# Altium schematic sheet Page A_Power.SchDoc
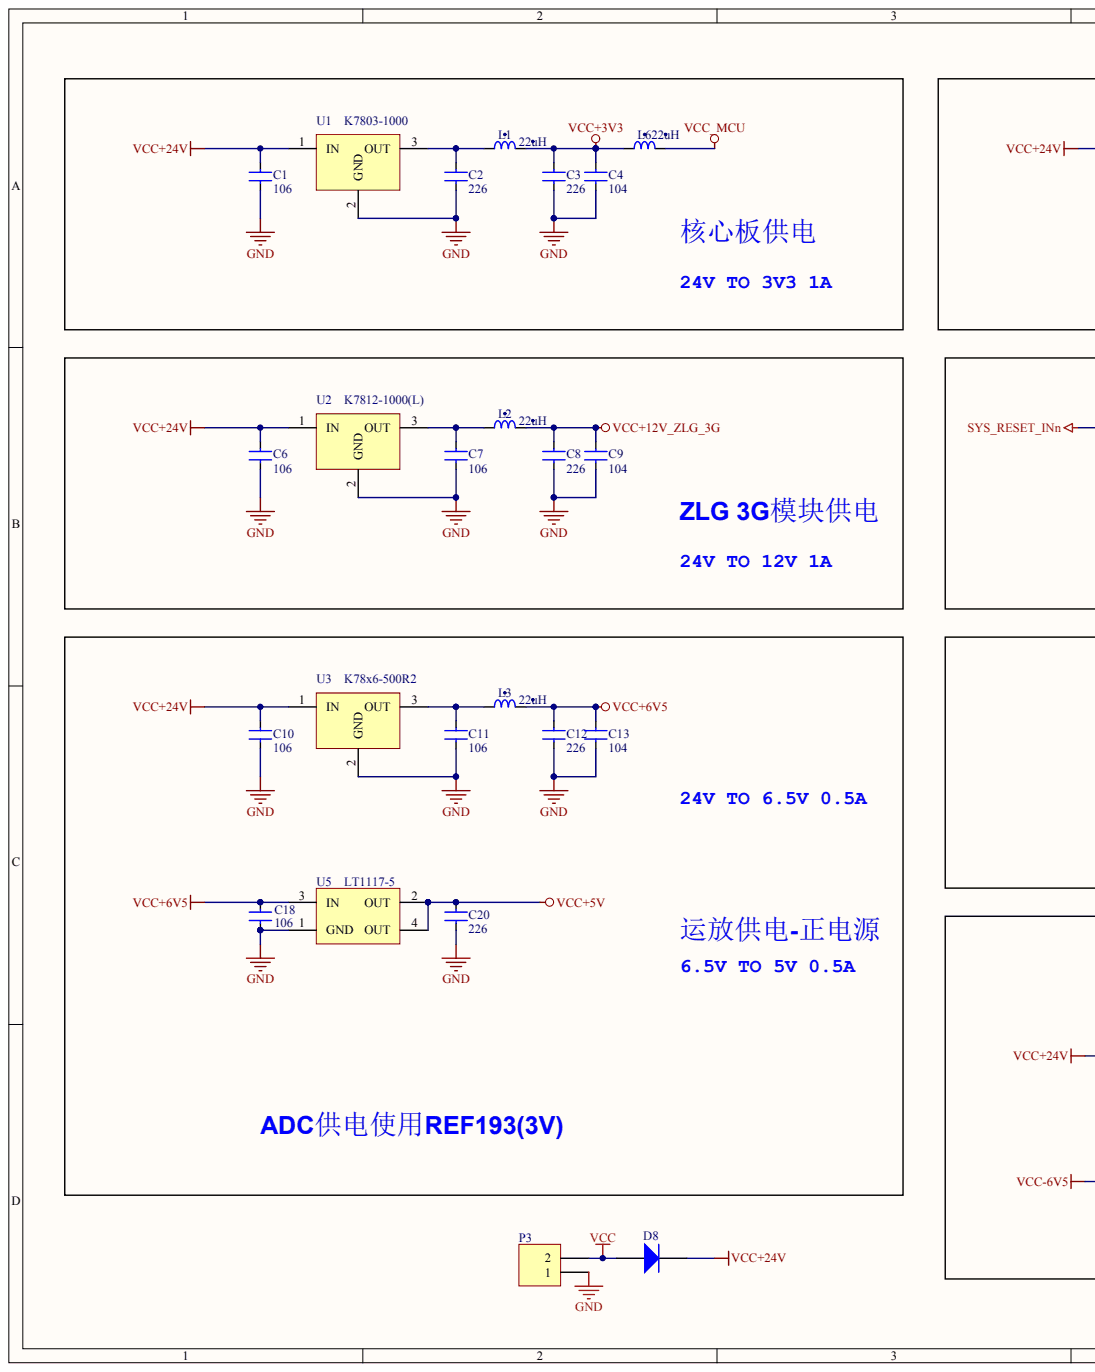
[diagram p0: Page A_Power.SchDoc, left]
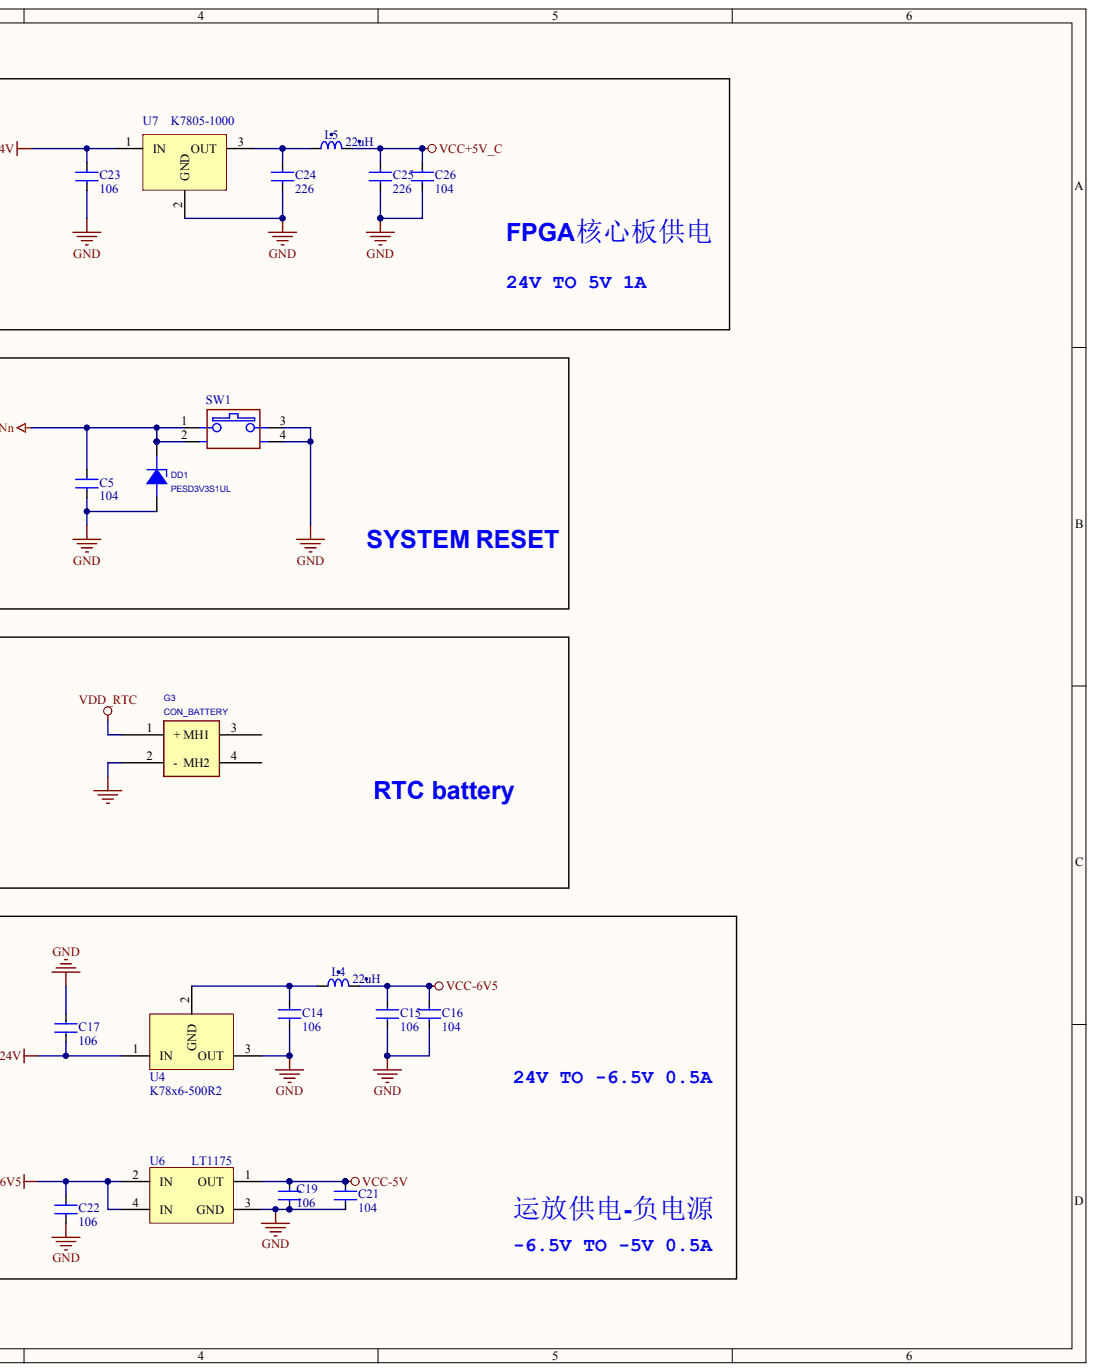
[diagram p1: Page A_Power.SchDoc, right]

## Parts
C1  106
C2  226
C3  226
C4  104
C5  104
C6  106
C7  106
C8  226
C9  104
C10  106
C11  106
C12  226
C13  104
C14  106
C15  106
C16  104
C17  106
C18  106
C19  106
C20  226
C21  104
C22  106
C23  106
C24  226
C25  226
C26  104
D8  1N4007  (value not drawn)
DD1  PESD3V3S1UL
G3  CON_BATTERY
L1  22uH  [INDC1608X95N]
L2  22uH  [INDC1608X95N]
L3  22uH  [INDC1608X95N]
L4  22uH  [INDC1608X95N]
L5  22uH  [INDC1608X95N]
L6  22uH  [INDC1608X95N]
P3  Header 2  (value not drawn)
SW1  SW PUSHBUTTON-SPST SHIELD_0  (value not drawn)
U1  K7803-1000
U2  K7812-1000(L)
U3  K78x6-500R2
U4  K78x6-500R2
U5  LT1117-5
U6  LT1175
U7  K7805-1000

## Nets
GND: C1.2 C2.2 C3.2 C4.2 C5.2 C6.2 C7.2 C8.2 C9.2 C10.2 C11.2 C12.2 C13.2 C14.2 C15.2 C16.2 C17.2 C18.2 C19.2 C20.2 C21.2 C22.2 C23.2 C24.2 C25.2 C26.2 DD1.2 G3.2(-) P3.1 SW1.3 SW1.4 U1.2 U2.2 U3.2 U4.3 U5.1(GND) U6.3(GND) U7.2
SYS_RESET_INN: C5.1 DD1.1 SW1.1 SW1.2
VCC: D8.1 P3.2
VCC+3V3: C3.1 C4.1 L1.2 L6.1
VCC+5V: C20.1 U5.2(OUT) U5.4(OUT)
VCC+5V_C: C25.1 C26.1 L5.2
VCC+6V5: C12.1 C13.1 C18.1 L3.2 U5.3(IN)
VCC+12V_ZLG_3G: C8.1 C9.1 L2.2
VCC+24V: C1.1 C6.1 C10.1 C17.1 C23.1 D8.2 U1.1 U2.1 U3.1 U4.1 U7.1
VCC-5V: C19.1 C21.1 U6.1(OUT)
VCC-6V5: C15.1 C16.1 C22.1 L4.2 U6.2(IN) U6.4(IN)
VCC_MCU: L6.2
VDD_RTC: G3.1(+)
NetC2_1: C2.1 L1.1 U1.3
NetC7_1: C7.1 L2.1 U2.3
NetC11_1: C11.1 L3.1 U3.3
NetC14_1: C14.1 L4.1 U4.2
NetC24_1: C24.1 L5.1 U7.3

Not connected: G3 3(MH1) 4(MH2)

## Library data of the parts
DD1 = (no symbol name): Manufacturer: NXP; Remark: ESD; Manufacturer PartNumber: PESD3V3S1UL
G3 = (no symbol name): Remark: CR1220纽扣电池座
L1-L6 = (no symbol name): Part Number: IND0603_TDK_MPZ1608R391A; Part Description: SMT Chip Bead for Power Line; Manufacturer: TDK; Manufacturer Part Number: MPZ1608R391A; Value: 390R@100MHz; Power: 1.2A; Resistance: 0.12R; Tolerance: ?5%; Table: Inductors SMD; Component Kind: Standard; Component Type: Standard; Pin Count: 2; Status: None; Case: 0603; Mounted: Yes; Socket: No; SMD: Yes; ComponentHeight: 0.95mm; HelpURL: \\cern.ch\dfs\Applications\Altium\Datasheets\IND0603_TDK_MPZ1608.pdf; CreateDate: 02.12.2009; LatestRevisionDate: 02.12.2009; Author: CERN DEM JLC
C1-C26 D8 P3 SW1 U1-U7 = (no symbol name)
  D8: Published: 8-Jun-2000; LatestRevisionDate: 17-Jul-2002; LatestRevisionNote: Re-released for DXP Platform.; DatasheetDocument: 1997; PackageReference: DO-35; Code_JEDEC: DO-204-AH; ComponentLink1Description: Manufacturer Link; ComponentLink1URL: http://www.fairchildsemi.com/; ComponentLink2Description: Datasheet; ComponentLink2URL: http://www.fairchildsemi.com/ds/1N%2F1N4148.pdf
  P3: LatestRevisionDate: 17-Jul-2002; LatestRevisionNote: Re-released for DXP Platform.
  SW1: Filename: *
  D8 P3: Publisher: Altium Limited
